# Altium schematic sheet [4] InputOutput.SchDoc("[4] InputOutput")
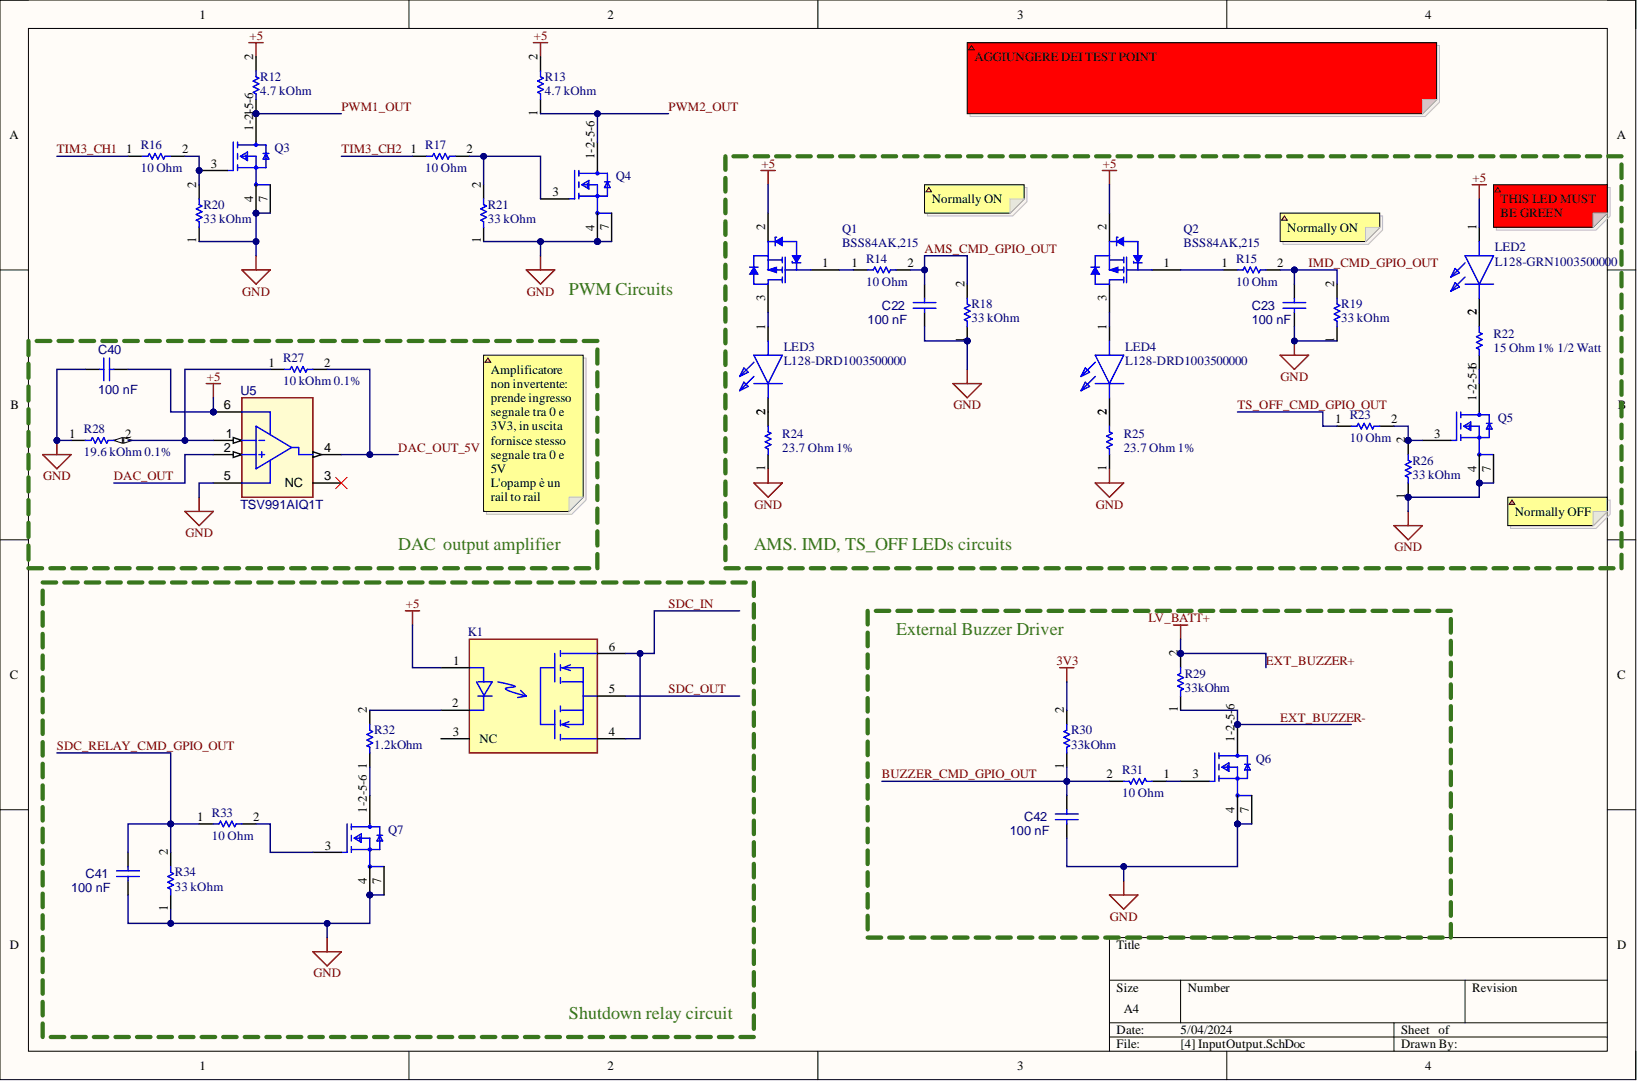
[diagram p0: [4] InputOutput.SchDoc]

## Parts
C22  100 nF
C23  100 nF
C40  100 nF
C41  100 nF
C42  100 nF
K1  Solid-State Relay  (value not drawn)
LED2  L128-GRN1003500000
LED3  L128-DRD1003500000
LED4  L128-DRD1003500000
Q1  BSS84AK,215
Q2  BSS84AK,215
Q3  SIA440DJ-T1-GE3  (value not drawn)
Q4  SIA440DJ-T1-GE3  (value not drawn)
Q5  SIA440DJ-T1-GE3  (value not drawn)
Q6  SIA440DJ-T1-GE3  (value not drawn)
Q7  SIA440DJ-T1-GE3  (value not drawn)
R12  4.7 kOhm
R13  4.7 kOhm
R14  10 Ohm
R15  10 Ohm
R16  10 Ohm
R17  10 Ohm
R18  33 kOhm
R19  33 kOhm
R20  33 kOhm
R21  33 kOhm
R22  15 Ohm 1% 1/2 Watt
R23  10 Ohm
R24  23.7 Ohm 1%
R25  23.7 Ohm 1%
R26  33 kOhm
R27  10 kOhm 0.1%
R28  19.6 kOhm 0.1%
R29  33kOhm
R30  33kOhm
R31  10 Ohm
R32  1.2kOhm
R33  10 Ohm
R34  33 kOhm
U5  TSV991AIQ1T

## Nets
3V3: R30.2
+5: C40.2 K1.1 LED2.1 Q1.2 Q2.2 R12.2 R13.2 U5.6
GND: C22.2 C23.2 C40.1 C41.2 C42.2 Q3.4 Q3.7 Q4.4 Q4.7 Q5.4 Q5.7 Q6.4 Q6.7 Q7.4 Q7.7 R18.1 R19.1 R20.1 R21.1 R24.1 R25.1 R26.1 R28.1 R34.1 U5.5
AMS_CMD_GPIO_OUT: C22.1 R14.2 R18.2
BUZZER_CMD_GPIO_OUT: C42.1 R30.1 R31.2
DAC_OUT: U5.2
DAC_OUT_5V: R27.2 U5.4
EXT_BUZZER+: R29.2
EXT_BUZZER-: Q6.1-2-5-6 R29.1
IMD_CMD_GPIO_OUT: C23.1 R15.2 R19.2
PWM1_OUT: Q3.1-2-5-6 R12.1
PWM2_OUT: Q4.1-2-5-6 R13.1
SDC_IN: K1.4 K1.6
SDC_OUT: K1.5
SDC_RELAY_CMD_GPIO_OUT: C41.1 R33.1 R34.2
TIM3_CH1: R16.1
TIM3_CH2: R17.1
TS_OFF_CMD_GPIO_OUT: R23.1
NetK1_2: K1.2 R32.2
NetLED2_2: LED2.2 R22.2
NetLED3_1: LED3.1 Q1.3
NetLED3_2: LED3.2 R24.2
NetLED4_1: LED4.1 Q2.3
NetLED4_2: LED4.2 R25.2
NetQ1_1: Q1.1 R14.1
NetQ2_1: Q2.1 R15.1
NetQ3_3: Q3.3 R16.2 R20.2
NetQ4_3: Q4.3 R17.2 R21.2
NetQ5_1-2-5-6: Q5.1-2-5-6 R22.1
NetQ5_3: Q5.3 R23.2 R26.2
NetQ6_3: Q6.3 R31.1
NetQ7_1-2-5-6: Q7.1-2-5-6 R32.1
NetQ7_3: Q7.3 R33.2
NetR27_1: R27.1 R28.2 U5.1

Not connected: K1 3(NC); U5 3(NC)

## Library data of the parts
C22 C23 C40-C42 = CMP-001-00085-5: VJ0805Y104MXATW1BC; Tech: SMD; Component Kind: Standard; ComponentLink1Description: Website; Package: 0805; Library Name: EMEA- Squadra Corse PoliTO-  Politecnico di Torino
K1 = CMP-2000-07398-2: VO14642AABTR; Manufacturer: Vishay; Input Current: 50mA; Isolation Voltage: 5300Vrms; Max Junction Temp: +125°C; Max Power Dissipation: 330mW; Number Of Contacts: 1; On State Resistance: 0.25Ω; Output Current: 2A; Output Voltage: 60V; Packag: SMD DIP-6; Standoff Height: 0.05mm; Tech: SMD; Throw Configuration: SPST-NO; Ambient Temperature Range High: +85°C; Ambient Temperature Range Low: -55°C; Automotive: No; Category: Relay; Component Kind: Standard; ComponentLink2Description: Datasheet; ComponentLink2URL: http://www.vishay.com/docs/81646/vo14642a.pdf; ComponentLink3Description: Website; ComponentLink3URL: https://www.mouser.it/ProductDetail/Vishay-Semiconductors/VO14642AABTR?qs=%2Fjqivxn91cdjDkQHAFlOvw%3D%3D; Device Class L1: Electromechanical; Device Class L2: Relays; Device Class L3: Solid State Relays; Digikey Description: SSR RELAY SPST-NO 2A 0-60V; Height: 4.25mm; Ipc Land Pattern Name: SOIC254P980X425-6; Lead Free: Yes; Library Name: Altium Content Vault; Manufacturer Part Number: VO14642AABTR; Mouser Description: Solid State Relays - PCB Mount Normally Open Form 1A 60V; Octopart: https://octopart.com/vo14642aabtr-vishay-42763680; Package: VO14642AABTR; RoHS: TRUE; Temperature: to +125°C; Temperature Range High: +125°C
LED2 = CMP-147-000000-2: 3.3 V Green LED 2835  SMD; Manufacturer_Name: Lumileds; Arrow Part Number: L128-GRN1003500000; Arrow Price/Stock: https://www.arrow.com/en/products/l128-grn1003500000/lumileds?region=europe; Component Kind: Standard; Datasheet Link: https://www.mouser.in/datasheet/2/602/DS236-luxeon-2835-color-line-datasheet-1596094.pdf; Design Item ID: L128-GRN1003500000; Height: 0.75mm; Manufacturer_Part_Number: L128-GRN1003500000; Mouser Part Number: 997-L128GRN10350; Mouser Price/Stock: https://www.mouser.co.uk/ProductDetail/Lumileds/L128-GRN1003500000?qs=T3oQrply3y98L%2F5KYOxuLA%3D%3D; Source: Schlib1.SchLib; Library Name: EMEA- Squadra Corse PoliTO-  Politecnico di Torino
LED3 LED4 = CMP-031-000018-2: LED; Manufacturer_Name: Lumileds; Arrow Part Number: L128-DRD1003500000; Arrow Price/Stock: https://www.arrow.com/en/products/l128-drd1003500000/lumileds?region=europe; Component Kind: Standard; Datasheet Link: https://www.mouser.in/datasheet/2/602/DS236_luxeon_2835_color_line_datasheet-1596094.pdf; Design Item ID: L128-DRD1003500000; Height: 0.75mm; Manufacturer_Part_Number: L128-DRD1003500000; Mouser Part Number: 997-L128DRD10350; Mouser Price/Stock: https://www.mouser.co.uk/ProductDetail/Lumileds/L128-DRD1003500000?qs=T3oQrply3y80axb1i4CkEA%3D%3D; Source: SamacSys.SchLib; Library Name: EMEA- Squadra Corse PoliTO-  Politecnico di Torino
Q1 Q2 = CMP-1259-00086-1: P-Channel Trench MOSFET, -50 V, -0.18 A, -55 to 150 degC, 3-Pin SOT23, Tape and Reel; Manufacturer: NXP Semiconductors; Automotive Qualified: Y; Channel Type: P; IDM [max] (A): -0.7; Packing: Tape & Reel; VGSth [typ] (V): -1.6; Code_JEDEC: TO-236AB; Component Kind: Standard; ComponentLink1Description: Manufacturer URL; ComponentLink1URL: http://www.nxp.com/; ComponentLink2Description: Datasheet; ComponentLink2URL: http://www.nxp.com/documents/data_sheet/BSS84AK.pdf; ComponentLink3Description: Package Specification; ComponentLink3URL: http://www.nxp.com/documents/outline_drawing/sot023_po.pdf; DatasheetVersion: Rev. 1; ID [max] (A): -0.18; Library Name: Altium Content Vault; Mounting Technology: Surface Mount; PackageDescription: 3-Pin Small Outline Transistor Package, Body 2.9 x 1.3 mm, Pitch 0.95 mm; PackageReference: SOT23; PackageVersion: 06-03-16, 03/2006; PartNumber: BSS84AK,215; VDS [max] (V): -50
Q3-Q7 = CMP-02550-000315-1: MOSFET N-CH 40V 12A PPAK SC70-6; Drain to Source Resistance: 26mR; Element Configuration: Single; Fall Time: 5ns; Gate to Source Voltage (Vgs): 12V; Input Capacitance: 700pF; Max Power Dissipation: 19W; Number of Channels: 1; Polarity: N-CHANNEL; Rise Time: 32ns; Turn-Off Delay Time: 23ns; Turn-On Delay Time: 12ns; Component Kind: Standard; Continuous Drain Current (ID): 12A; Drain to Source Breakdown Voltage: 40V; Drain to Source Voltage (Vdss): 40V; Height: 0.75mm; Length: 2.05mm; Library Name: Altium Content Vault; Max Operating Temperature: 150°C; Min Operating Temperature: -55°C; Mount: Surface Mount; Number of Pins: 6; Package Quantity: 3000; Packaging: Tape and Reel; Radiation Hardening: No; Rds On Max: 26mR; RoHS Compliant: Yes; Series: TrenchFET®; Width: 2.05mm
R12 R13 = CMP-00010-3: CRCW08054K70JNEA; Component Kind: Standard; Library Name: EMEA- Squadra Corse PoliTO-  Politecnico di Torino
R14-R17 R23 R33 = CMP-00010-3: CRCW060310R0FKEAHP; Component Kind: Standard; Library Name: EMEA- Squadra Corse PoliTO-  Politecnico di Torino
R18-R21 R26 R34 = CMP-00010-3: CRCW060333K0JNEA; Component Kind: Standard; Library Name: EMEA- Squadra Corse PoliTO-  Politecnico di Torino
R22 = CMP-009-00178-2: CRCW121015R0FKTA; Tech: SMD; Component Kind: Standard; ComponentLink1Description: Website; Package: 1210; Library Name: EMEA- Squadra Corse PoliTO-  Politecnico di Torino
R24 R25 = CMP-009-00178-2: CRCW121023R7FKEA; Tech: SMD; Component Kind: Standard; ComponentLink1Description: Website; Package: 1210; Library Name: EMEA- Squadra Corse PoliTO-  Politecnico di Torino
R27 = CMP-00010-3: TNPW060310K0BEEA; Component Kind: Standard; Library Name: EMEA- Squadra Corse PoliTO-  Politecnico di Torino
R28 = CMP-00010-3: TNPW060319K6BEEA; Component Kind: Standard; Library Name: EMEA- Squadra Corse PoliTO-  Politecnico di Torino
R29 R30 = CMP-009-00179-5: CRCW060333K0FKEAC; Tech: SMD; Component Kind: Standard; ComponentLink1Description: Website; Package: 0603; Library Name: EMEA- Squadra Corse PoliTO-  Politecnico di Torino
R31 = CMP-009-00179-5: CRCW060310R0FKEAHP; Tech: SMD; Component Kind: Standard; ComponentLink1Description: Website; Package: 0603; Library Name: EMEA- Squadra Corse PoliTO-  Politecnico di Torino
R32 = CMP-009-00179-5: CRCW06031K20FKEAHP; Tech: SMD; Component Kind: Standard; ComponentLink1Description: Website; Package: 0603; Library Name: EMEA- Squadra Corse PoliTO-  Politecnico di Torino
U5 = CMP-014-00000-3: Rail-to-rail Input / Output GBP Operational Amplifier, 20 MHz, -40 to 125 degC, 6-Pin DFN, RoHS, Tape and Reel; Channels: 2; Component Kind: Standard; Max Operating Temperature: 125°C; Min Operating Temperature: -40°C; Pins: 6; Library Name: EMEA- Squadra Corse PoliTO-  Politecnico di Torino
